# Altium schematic sheet 02_DpLED.SchDoc(02_DpLED)
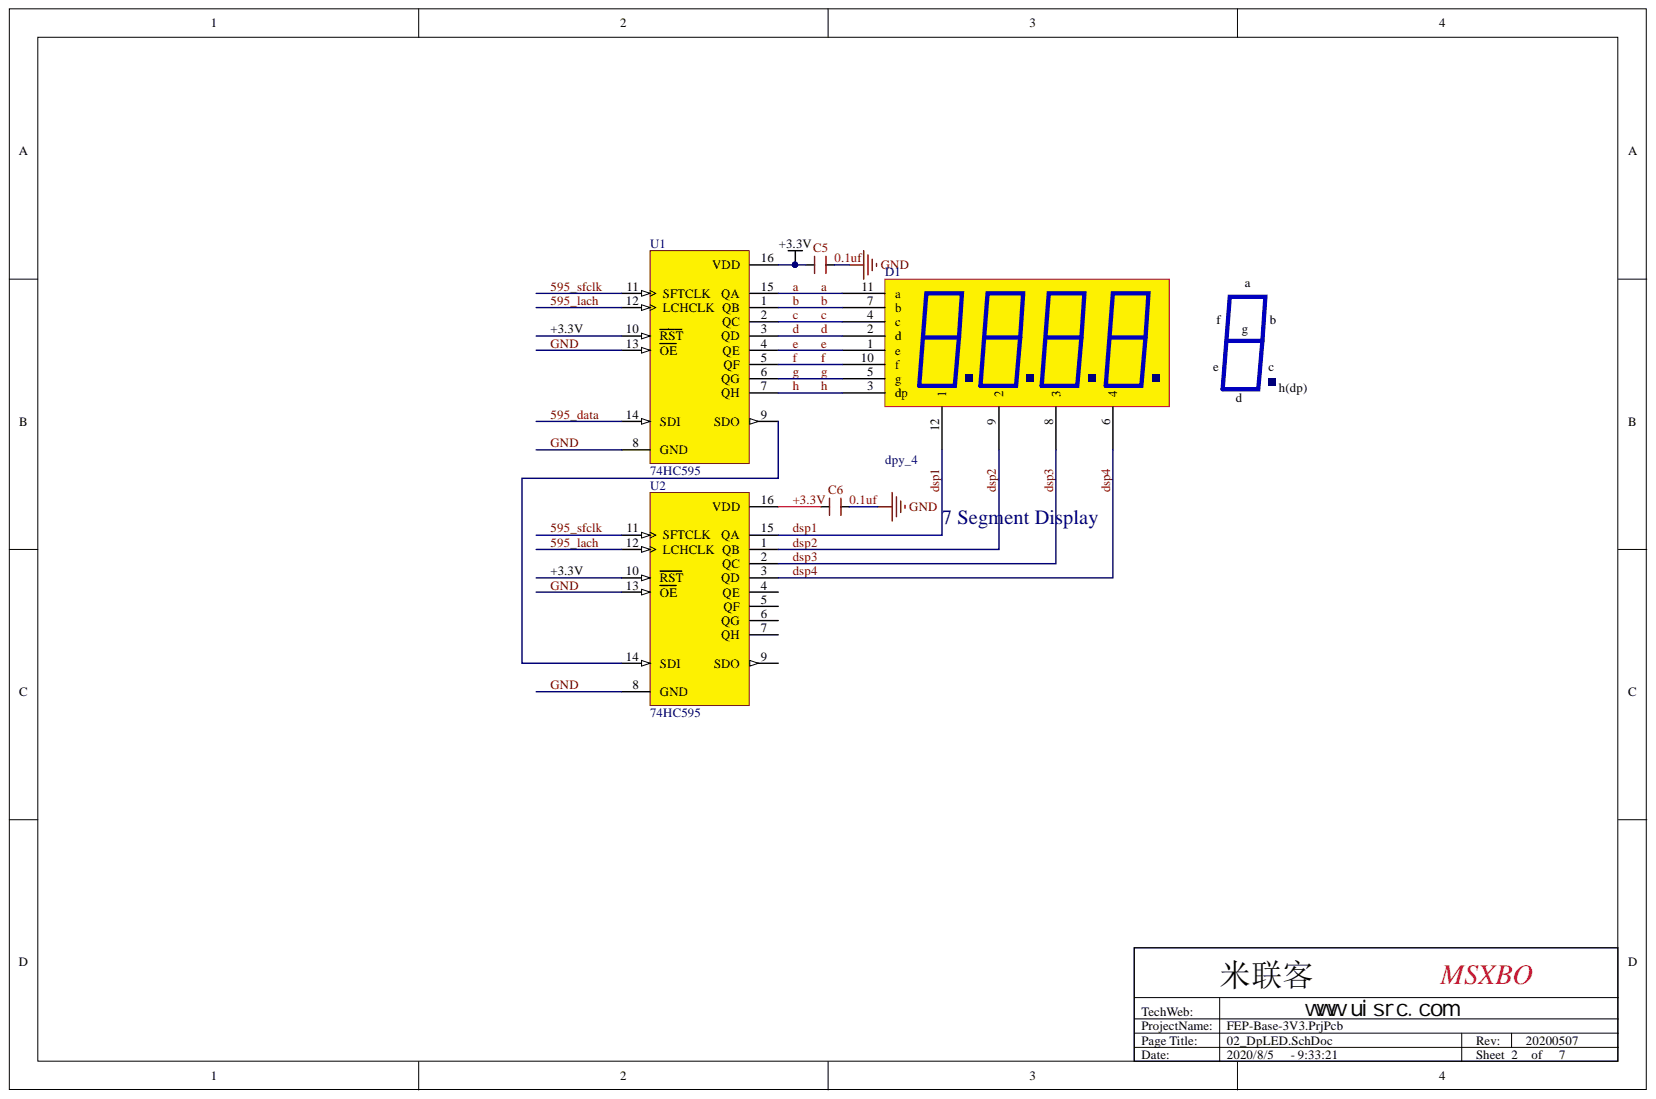
[diagram p0: 02_DpLED.SchDoc]

## Parts
C5  0.1uf  [CAP0402]
C6  0.1uf  [CAP0402]
D1  dpy_4  [DPY_4_1]
U1  74HC595  [SOP16W]
U2  74HC595  [SOP16W]

## Nets
595_DATA: U1.14(SDI)
595_LACH: U1.12(LCHCLK) U2.12(LCHCLK)
595_SFCLK: U1.11(SFTCLK) U2.11(SFTCLK)
+3.3V: C5.2 C6.2 U1.10(RST) U1.16(VDD) U2.10(RST) U2.16(VDD)
A: D1.11(a) U1.15(QA)
B: D1.7(b) U1.1(QB)
C: D1.4(c) U1.2(QC)
D: D1.2(d) U1.3(QD)
DSP1: D1.12 U2.15(QA)
DSP2: D1.9 U2.1(QB)
DSP3: D1.8 U2.2(QC)
DSP4: D1.6 U2.3(QD)
E: D1.1(e) U1.4(QE)
F: D1.10(f) U1.5(QF)
G: D1.5(g) U1.6(QG)
GND: C5.1 C6.1 U1.8(GND) U1.13(OE) U2.8(GND) U2.13(OE)
H: D1.3(dp) U1.7(QH)
NetU1_9: U1.9(SDO) U2.14(SDI)

Not connected: U2 4(QE) 5(QF) 6(QG) 7(QH) 9(SDO)

## Library data of the parts
C5 C6 = CAPACITOR: Generic Capacitor; Component Kind: Standard; Value: 0.1uf; Library Name: Miz701_fun20160215.IntLib
D1 = dpy_4: Component Kind: Standard; Library Name: FEP-Base-3V3.SCHLIB
U1 U2 = 74HC595: Component Kind: Standard; Library Name: FEP-Base-3V3.SCHLIB
